# Altium schematic sheet CompSchm.SchDoc
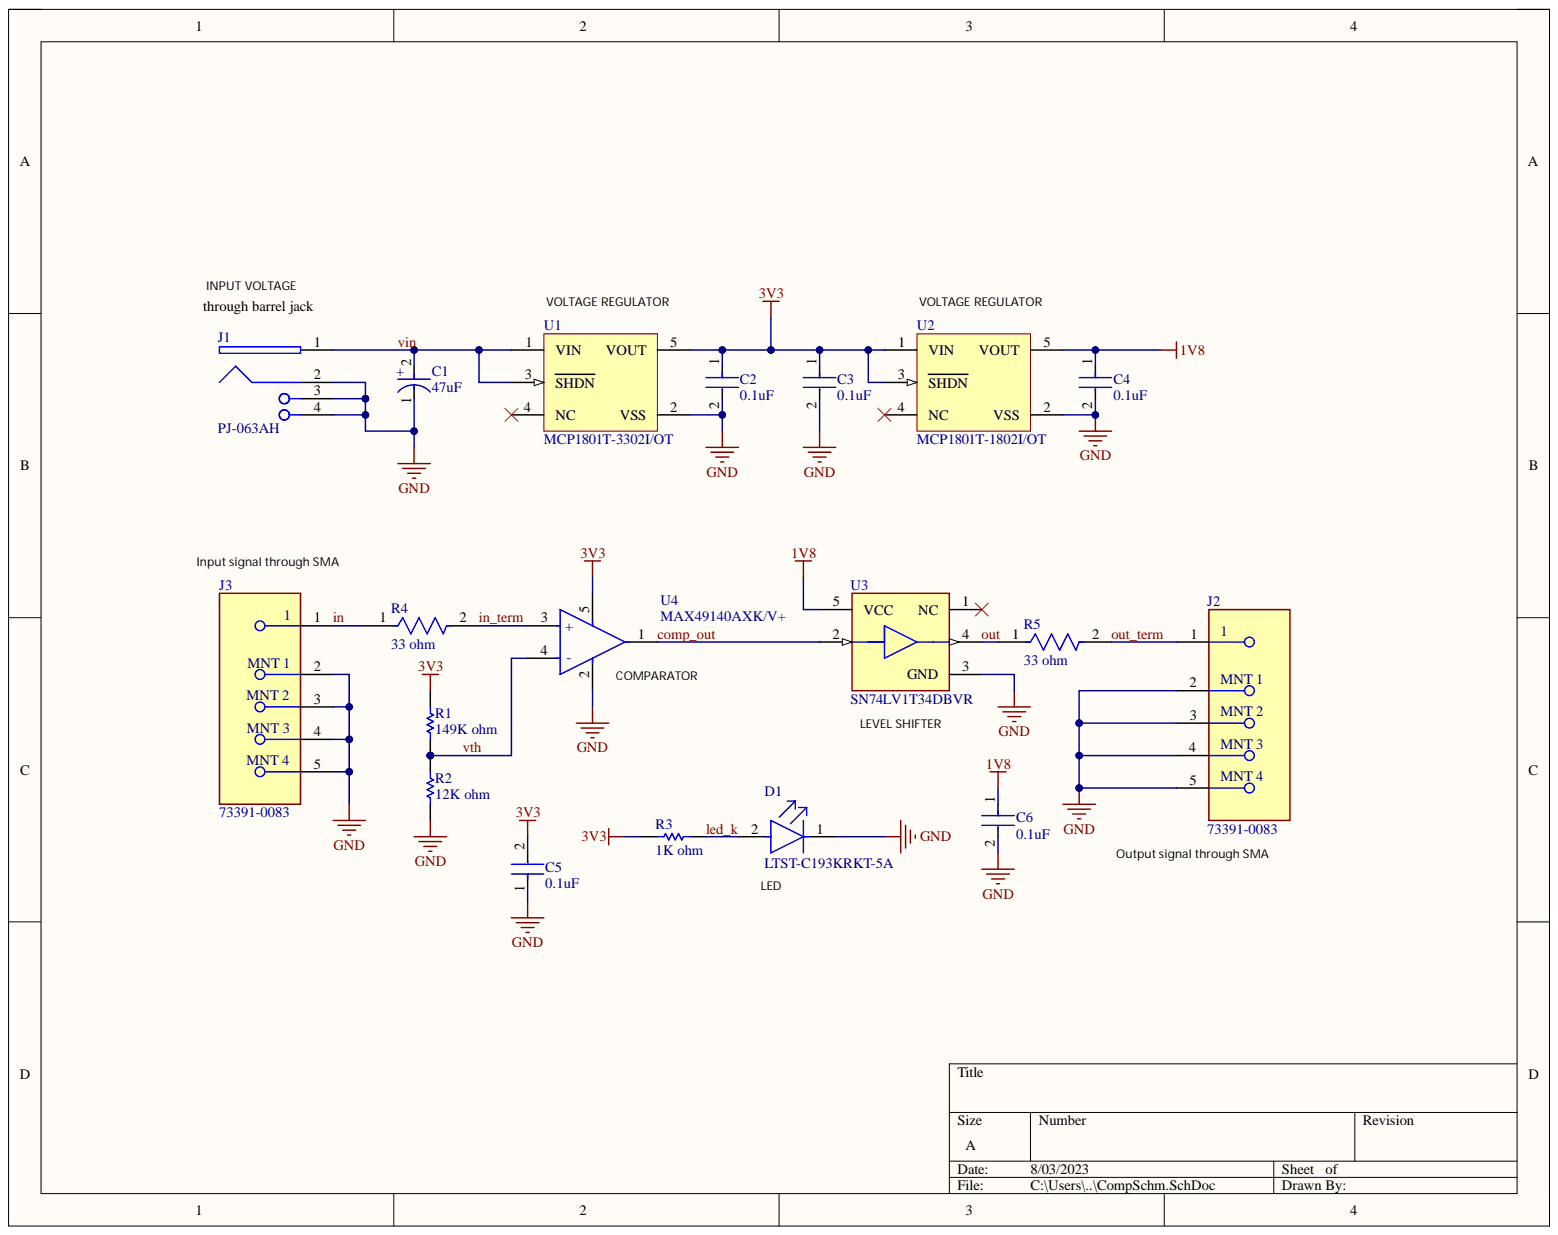
[diagram p0: CompSchm.SchDoc]

## Parts
C1  47uF  [FP-T491X476K035AT-MFG]
C2  0.1uF  [FP-CL21-IPC_C]
C3  0.1uF  [FP-CL21-IPC_C]
C4  0.1uF  [FP-CL21-IPC_C]
C5  0.1uF  [FP-CL21-IPC_C]
C6  0.1uF  [FP-CL21-IPC_C]
D1  LTST-C193KRKT-5A  [FP-LTST-C193KRKT-5A-MFG]
J1  PJ-063AH  [CUI-PJ-063AH_V]
J2  73391-0083  [FP-73391-0083-MFG]
J3  73391-0083  [FP-73391-0083-MFG]
R1  149K ohm  [RESC2013X50X40NL20T20]
R2  12K ohm  [RESC2013X50X40NL20T20]
R3  1K ohm  [RESC2013X50X40NL20T20]
R4  33 ohm  [FP-CRGCQ0805-IPC_C]
R5  33 ohm  [FP-CRGCQ0805-IPC_C]
U1  MCP1801T-3302I/OT  [SOT-23-OT5_M]
U2  MCP1801T-1802I/OT  [SOT-23-OT5_M]
U3  SN74LV1T34DBVR  [FP-DBV0005A-IPC_B]
U4  MAX49140AXK/V+  [FP-X5-1C-MFG]

## Nets
1V8: C4.1 C6.1 U2.5(VOUT) U3.5(VCC)
3V3: C2.1 C3.1 C5.2 R1.2 R3.2 U1.5(VOUT) U2.1(VIN) U2.3(SHDN) U4.5
GND: C1.1 C2.2 C3.2 C4.2 C5.1 C6.2 D1.1 J1.2 J1.3 J1.4 J2.2 J2.3 J2.4 J2.5 J3.2 J3.3 J3.4 J3.5 R2.1 U1.2(VSS) U2.2(VSS) U3.3(GND) U4.2
comp_out: U3.2 U4.1
in: J3.1 R4.1
in_term: R4.2 U4.3(+)
led_k: D1.2 R3.1
out: R5.1 U3.4
out_term: J2.1 R5.2
vin: C1.2 J1.1 U1.1(VIN) U1.3(SHDN)
vth: R1.1 R2.2 U4.4(-)

Not connected: U1 4(NC); U2 4(NC); U3 1(NC)

## Library data of the parts
C1 = CMP-03017-000044-1: CAP TANT 47UF 10% 35V 2917 Part: T491X476K035AT; Dielectric Material: Tantalum; Dissipation Factor: 8%; ESR (Equivalent Series Resistance): 600mR; Leakage Current: 16.5uA; Life (Hours): 2000Hour; Polarity: Polar; Ripple Current: 524mA; Capacitance: 47uF; Case Code (Imperial): 2917; Case Code (Metric): 7343; Case/Package: 2917; Component Kind: Standard; Construction: Flat; Depth: 4.3mm; Features: General Purpose; Height: 4mm; Height - Seated (Max): 0.169inch; Lead Free: Lead Free; Length: 7.3mm; Library Name: Altium Content Vault; Max Operating Temperature: 125°C; Min Operating Temperature: -55°C; Mount: Surface Mount; Number of Pins: 2; Package Quantity: 1; Packaging: Tape and Reel; Radiation Hardening: No; REACH SVHC: No SVHC; RoHS Compliant: Yes; Series: T491; Termination: SMD/SMT; Tolerance: 10%; Voltage: 35V; Voltage Rating: 35V; Voltage Rating (DC): 35V; Width: 4.3mm
C2-C6 = CMP-13271-002854-1: CL21 Series 0805 100nF 25V ±10% Tolerance X7R Multilayer Ceramic Chip Capacitor; Capacitance: 100nF; Case Code (Imperial): 0805; Case Code (Metric): 2012; Case/Package: 0805; Component Kind: Standard; Contact Plating: Tin; Depth: 1.25mm; Dielectric: X7R; Height: 0.85mm; Length: 2mm; Library Name: Altium Content Vault; Max Operating Temperature: 125°C; Min Operating Temperature: -55°C; Mount: Surface Mount; Package Quantity: 1; Packaging: Tape and Reel; Radiation Hardening: No; Thickness: 0.037inch; Tolerance: 10%; Voltage: 25V; Voltage Rating: 25V; Width: 0.049inch
D1 = CMP-2000-06623-2: LED RED CLEAR CHIP SMD; Dominant Wavelength: 631nm; Forward Current: 5mA; Forward Voltage: 2.3V; Lens Color: Colorless; Lens Style: Diffused; Luminous Intensity: 45mcd; Number of Elements: 1; Power Dissipation: 50mW; Reverse Voltage: 5V; Viewing Angle: 130°; Case Code (Imperial): 0603; Case Code (Metric): 1608; Case/Package: 0603; Color: Red; Component Kind: Standard; Depth: 0.8mm; Height: 0.35mm; Illumination Color: Red; Lead Free: Lead Free; Length: 1.6mm; Lens Transparency: Clear; Library Name: Altium Content Vault; Max Operating Temperature: 85°C; Min Operating Temperature: -55°C; Mount: Surface Mount; Number of LEDs: 1; Number of Pins: 2; Package Quantity: 3000; Packaging: Tape and Reel; Radiation Hardening: No; Test Current: 5mA; Width: 0.8mm
J1 = CMP-2000-06898-1: Through Hole Right Angle DC Power Jack, 8 A, 2 mm Center Pin, 2 Position, -25 to 85 degC, RoHS, Bulk; Manufacturer: CUIInc.; Brand: CUI; Current Rating: 8A; Inside Contact Diameter: 2mm; Insulation Resistance: 100MOhms; Outside Contact Diameter: 6.5mm; Voltage Rating: 24V; Component Kind: Standard; Connector Type: DCPowerJack; Contact Gender: Male; Contact Material: Copper; Contact Plating: Nickel; Datasheet URL: http://www.cui.com/product/resource/pj-063ah.pdf; Datasheet Version: Rev. 1.02, 03/2016; Factory Pack Quantity: 500; Flammability Rating: UL94V-0; Housing Material: PolybutyleneTerephthalate(PBT); Library Name: Altium Content Vault; Manufacturer URL: http://www.cui.com/; Mounting Angle: Right; Mounting Style: KinkedPin; Mounting Technology: ThroughHole; Operating Temperature Range: -25Cto+85C; Package Description: 2-Pin Through Hole Device, Body 13 x 10 mm, Height 9 mm; Package Reference: PJ-063AH; Product: Jacks; Product Category: DCPowerConnectors; RoHS: True; Termination Style: ThroughHole
J2 J3 = CMP-04764-000017-1: SMA RA JACK, PCB; Body Plating: Gold; Gender: Female; Impedance: 50R; Case/Package: SMA; Component Kind: Standard; Connector Type: SMA; Contact Material: Copper; Contact Plating: Gold; Library Name: Altium Content Vault; Mount: PCB; Orientation: Right Angle; REACH SVHC: No SVHC; RoHS Compliant: Yes; Termination: Solder
R1-R3 = CMP-2001-04812-1: Manufacturer: Susumu; Part Number: RG2012N-123-W-T1; Component Kind: Standard; Library Name: Altium Content Vault
R4 R5 = CMP-03211-008574-1: CRGCQ0805 33Ω 0.125W ±200ppm/°C 1% 150V; Manufacturer: TE Connectivity; Manufacturer Part Number: CRGCQ0805F33R; Automotive Grade: Grade 343; Tolerance: 0.01; Ambient Temperature Range High: +70°C; Automotive: Yes; Category: Res; Component Kind: Standard; ComponentLink2Description: Datasheet; ComponentLink2URL: https://www.te.com/commerce/DocumentDelivery/DDEController?Action=showdoc&DocId=Data+Sheet%7F1773204-3%7F1%7Fpdf%7FEnglish%7FENG_DS_1773204-3_1.pdf%7F4-2176341-352; Composition: Thick Film; Device Class L1: Passive Components; Device Class L2: Resistors; Device Class L3: Chip SMD Resistors; Height: 0.65mm; Ipc Land Pattern Name: RESC200125X55; Lead Free: Yes; Library Name: Altium Content Vault; Octopart: https://octopart.com/crgcq0805f33r-te+connectivity-91018172; Package: CRGCQ0805; Power Rating: 0.125W; Resistance: 33Ω; RoHS: TRUE; Series: CRGCQ; Temperature: -55°C to +155°C; Temperature Coefficient: ±200ppm/°C; Temperature Range High: +155°C; Temperature Range Low: -55°C; Value: 33Ω; Voltage Rating: 150V
U1 = CMP-0136-00719-1: 150 mA, High PSRR, Low Quiescent Current LDO, 5-Pin SOT-23, Industrial Temperature, Tape and Reel; Code_IPC: SOT23-5; Component Kind: Standard; DatasheetVersion: revC, Jan-2009; Library Name: Altium Content Vault; PackageDescription: 5-Lead Plastic Small Outline Transistor (OT) [SOT-23]; PackageReference: SOT-23-OT5; PackageVersion: revBB, Aug-2009
U2 = CMP-0136-00723-1: 150 mA, High PSRR, Low Quiescent Current LDO, 5-Pin SOT-23, Industrial Temperature, Tape and Reel; Code_IPC: SOT23-5; Component Kind: Standard; DatasheetVersion: revC, Jan-2009; Library Name: Altium Content Vault; PackageDescription: 5-Lead Plastic Small Outline Transistor (OT) [SOT-23]; PackageReference: SOT-23-OT5; PackageVersion: revBB, Aug-2009
U3 = CMP-0859-00123-4: IC BUF NON-INVERT 5.5V SOT23-5; High Level Output Current: -8mA; Logic Function: Buffer; Low Level Output Current: 8mA; Min Supply Voltage: 1.6V; Number of Bits: 1; Polarity: Non-Inverting; Propagation Delay: 15.5ns; Quiescent Current: 1uA; Case/Package: SOT-23-5; Component Kind: Standard; Lead Free: Lead Free; Library Name: Altium Content Vault; Max Operating Temperature: 125°C; Max Supply Voltage: 5.5V; Min Operating Temperature: -40°C; Mount: Surface Mount; Number of Bits per Element: 1; Number of Channels: 1; Number of Elements: 1; Number of Input Lines: 1; Number of Inputs: 1; Number of Output Lines: 1; Number of Outputs: 1; Number of Pins: 5; Operating Supply Current: 8mA; Package Quantity: 3000; Packaging: Tape and Reel; Radiation Hardening: No; REACH SVHC: No SVHC; Weight: 0.000353oz
U4 = CMP-05700-000010-1: 30NS, LOW-POWER, 3V/5V, RAIL-TO-; Component Kind: Standard; Library Name: Altium Content Vault
